# Altium schematic sheet InfoDisplayLEDs.SchDoc
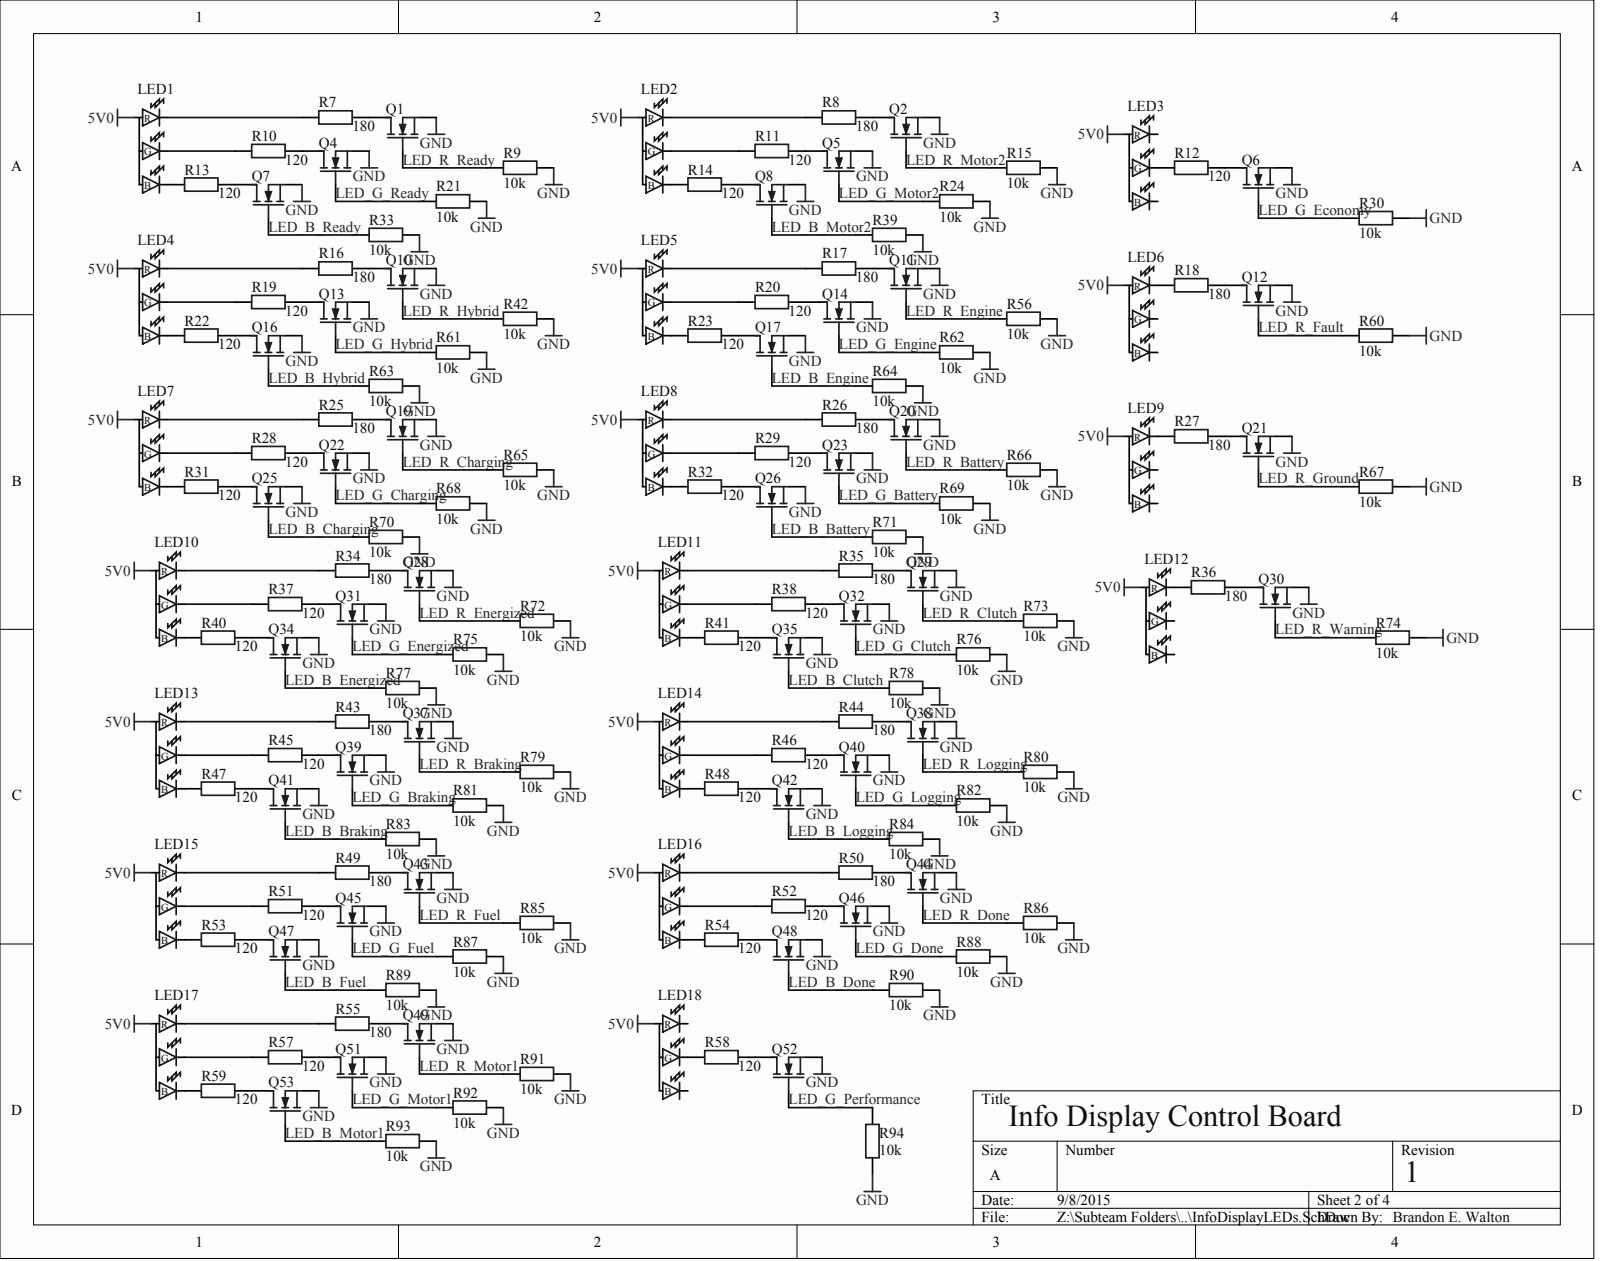
[diagram p0: InfoDisplayLEDs.SchDoc]

## Parts
LED1  LED
LED2  LED
LED3  LED
LED4  LED
LED5  LED
LED6  LED
LED7  LED
LED8  LED
LED9  LED
LED10  LED
LED11  LED
LED12  LED
LED13  LED
LED14  LED
LED15  LED
LED16  LED
LED17  LED
LED18  LED
Q1  MOSFET-N  (value not drawn)
Q2  MOSFET-N  (value not drawn)
Q4  MOSFET-N  (value not drawn)
Q5  MOSFET-N  (value not drawn)
Q6  MOSFET-N  (value not drawn)
Q7  MOSFET-N  (value not drawn)
Q8  MOSFET-N  (value not drawn)
Q10  MOSFET-N  (value not drawn)
Q11  MOSFET-N  (value not drawn)
Q12  MOSFET-N  (value not drawn)
Q13  MOSFET-N  (value not drawn)
Q14  MOSFET-N  (value not drawn)
Q16  MOSFET-N  (value not drawn)
Q17  MOSFET-N  (value not drawn)
Q19  MOSFET-N  (value not drawn)
Q20  MOSFET-N  (value not drawn)
Q21  MOSFET-N  (value not drawn)
Q22  MOSFET-N  (value not drawn)
Q23  MOSFET-N  (value not drawn)
Q25  MOSFET-N  (value not drawn)
Q26  MOSFET-N  (value not drawn)
Q28  MOSFET-N  (value not drawn)
Q29  MOSFET-N  (value not drawn)
Q30  MOSFET-N  (value not drawn)
Q31  MOSFET-N  (value not drawn)
Q32  MOSFET-N  (value not drawn)
Q34  MOSFET-N  (value not drawn)
Q35  MOSFET-N  (value not drawn)
Q37  MOSFET-N  (value not drawn)
Q38  MOSFET-N  (value not drawn)
Q39  MOSFET-N  (value not drawn)
Q40  MOSFET-N  (value not drawn)
Q41  MOSFET-N  (value not drawn)
Q42  MOSFET-N  (value not drawn)
Q43  MOSFET-N  (value not drawn)
Q44  MOSFET-N  (value not drawn)
Q45  MOSFET-N  (value not drawn)
Q46  MOSFET-N  (value not drawn)
Q47  MOSFET-N  (value not drawn)
Q48  MOSFET-N  (value not drawn)
Q49  MOSFET-N  (value not drawn)
Q51  MOSFET-N  (value not drawn)
Q52  MOSFET-N  (value not drawn)
Q53  MOSFET-N  (value not drawn)
R7  value 180  (hidden comment: Res2)
R8  value 180  (hidden comment: Res2)
R9  value 10k  (hidden comment: 311-10KGRCT-ND)
R10  value 120  (hidden comment: Res2)
R11  value 120  (hidden comment: Res2)
R12  value 120  (hidden comment: Res2)
R13  value 120  (hidden comment: Res2)
R14  value 120  (hidden comment: Res2)
R15  value 10k  (hidden comment: 311-10KGRCT-ND)
R16  value 180  (hidden comment: Res2)
R17  value 180  (hidden comment: Res2)
R18  value 180  (hidden comment: Res2)
R19  value 120  (hidden comment: Res2)
R20  value 120  (hidden comment: Res2)
R21  value 10k  (hidden comment: 311-10KGRCT-ND)
R22  value 120  (hidden comment: Res2)
R23  value 120  (hidden comment: Res2)
R24  value 10k  (hidden comment: 311-10KGRCT-ND)
R25  value 180  (hidden comment: Res2)
R26  value 180  (hidden comment: Res2)
R27  value 180  (hidden comment: Res2)
R28  value 120  (hidden comment: Res2)
R29  value 120  (hidden comment: Res2)
R30  value 10k  (hidden comment: 311-10KGRCT-ND)
R31  value 120  (hidden comment: Res2)
R32  value 120  (hidden comment: Res2)
R33  value 10k  (hidden comment: 311-10KGRCT-ND)
R34  value 180  (hidden comment: Res2)
R35  value 180  (hidden comment: Res2)
R36  value 180  (hidden comment: Res2)
R37  value 120  (hidden comment: Res2)
R38  value 120  (hidden comment: Res2)
R39  value 10k  (hidden comment: 311-10KGRCT-ND)
R40  value 120  (hidden comment: Res2)
R41  value 120  (hidden comment: Res2)
R42  value 10k  (hidden comment: 311-10KGRCT-ND)
R43  value 180  (hidden comment: Res2)
R44  value 180  (hidden comment: Res2)
R45  value 120  (hidden comment: Res2)
R46  value 120  (hidden comment: Res2)
R47  value 120  (hidden comment: Res2)
R48  value 120  (hidden comment: Res2)
R49  value 180  (hidden comment: Res2)
R50  value 180  (hidden comment: Res2)
R51  value 120  (hidden comment: Res2)
R52  value 120  (hidden comment: Res2)
R53  value 120  (hidden comment: Res2)
R54  value 120  (hidden comment: Res2)
R55  value 180  (hidden comment: Res2)
R56  value 10k  (hidden comment: 311-10KGRCT-ND)
R57  value 120  (hidden comment: Res2)
R58  value 120  (hidden comment: Res2)
R59  value 120  (hidden comment: Res2)
R60  value 10k  (hidden comment: 311-10KGRCT-ND)
R61  value 10k  (hidden comment: 311-10KGRCT-ND)
R62  value 10k  (hidden comment: 311-10KGRCT-ND)
R63  value 10k  (hidden comment: 311-10KGRCT-ND)
R64  value 10k  (hidden comment: 311-10KGRCT-ND)
R65  value 10k  (hidden comment: 311-10KGRCT-ND)
R66  value 10k  (hidden comment: 311-10KGRCT-ND)
R67  value 10k  (hidden comment: 311-10KGRCT-ND)
R68  value 10k  (hidden comment: 311-10KGRCT-ND)
R69  value 10k  (hidden comment: 311-10KGRCT-ND)
R70  value 10k  (hidden comment: 311-10KGRCT-ND)
R71  value 10k  (hidden comment: 311-10KGRCT-ND)
R72  value 10k  (hidden comment: 311-10KGRCT-ND)
R73  value 10k  (hidden comment: 311-10KGRCT-ND)
R74  value 10k  (hidden comment: 311-10KGRCT-ND)
R75  value 10k  (hidden comment: 311-10KGRCT-ND)
R76  value 10k  (hidden comment: 311-10KGRCT-ND)
R77  value 10k  (hidden comment: 311-10KGRCT-ND)
R78  value 10k  (hidden comment: 311-10KGRCT-ND)
R79  value 10k  (hidden comment: 311-10KGRCT-ND)
R80  value 10k  (hidden comment: 311-10KGRCT-ND)
R81  value 10k  (hidden comment: 311-10KGRCT-ND)
R82  value 10k  (hidden comment: 311-10KGRCT-ND)
R83  value 10k  (hidden comment: 311-10KGRCT-ND)
R84  value 10k  (hidden comment: 311-10KGRCT-ND)
R85  value 10k  (hidden comment: 311-10KGRCT-ND)
R86  value 10k  (hidden comment: 311-10KGRCT-ND)
R87  value 10k  (hidden comment: 311-10KGRCT-ND)
R88  value 10k  (hidden comment: 311-10KGRCT-ND)
R89  value 10k  (hidden comment: 311-10KGRCT-ND)
R90  value 10k  (hidden comment: 311-10KGRCT-ND)
R91  value 10k  (hidden comment: 311-10KGRCT-ND)
R92  value 10k  (hidden comment: 311-10KGRCT-ND)
R93  value 10k  (hidden comment: 311-10KGRCT-ND)
R94  value 10k  (hidden comment: 311-10KGRCT-ND)

## Nets
5V0: LED1.2(R) LED2.2(R) LED3.2(R) LED4.2(R) LED5.2(R) LED6.2(R) LED7.2(R) LED8.2(R) LED9.2(R) LED10.2(R) LED11.2(R) LED12.2(R) LED13.2(R) LED14.2(R) LED15.2(R) LED16.2(R) LED17.2(R) LED18.2(R)
GND: Q1.3 Q2.3 Q4.3 Q5.3 Q6.3 Q7.3 Q8.3 Q10.3 Q11.3 Q12.3 Q13.3 Q14.3 Q16.3 Q17.3 Q19.3 Q20.3 Q21.3 Q22.3 Q23.3 Q25.3 Q26.3 Q28.3 Q29.3 Q30.3 Q31.3 Q32.3 Q34.3 Q35.3 Q37.3 Q38.3 Q39.3 Q40.3 Q41.3 Q42.3 Q43.3 Q44.3 Q45.3 Q46.3 Q47.3 Q48.3 Q49.3 Q51.3 Q52.3 Q53.3 R9.2 R15.2 R21.2 R24.2 R30.1 R33.2 R39.2 R42.2 R56.2 R60.2 R61.2 R62.2 R63.2 R64.2 R65.2 R66.2 R67.2 R68.2 R69.2 R70.2 R71.2 R72.2 R73.2 R74.2 R75.2 R76.2 R77.2 R78.2 R79.2 R80.2 R81.2 R82.2 R83.2 R84.2 R85.2 R86.2 R87.2 R88.2 R89.2 R90.2 R91.2 R92.2 R93.2 R94.1
LED_B_BATTERY: Q26.2 R71.1
LED_B_BRAKING: Q41.2 R83.1
LED_B_CHARGING: Q25.2 R70.1
LED_B_CLUTCH: Q35.2 R78.1
LED_B_DONE: Q48.2 R90.1
LED_B_ENERGIZED: Q34.2 R77.1
LED_B_ENGINE: Q17.2 R64.1
LED_B_FUEL: Q47.2 R89.1
LED_B_HYBRID: Q16.2 R63.1
LED_B_LOGGING: Q42.2 R84.1
LED_B_MOTOR1: Q53.2 R93.1
LED_B_MOTOR2: Q8.2 R39.1
LED_B_READY: Q7.2 R33.1
LED_G_BATTERY: Q23.2 R69.1
LED_G_BRAKING: Q39.2 R81.1
LED_G_CHARGING: Q22.2 R68.1
LED_G_CLUTCH: Q32.2 R76.1
LED_G_DONE: Q46.2 R88.1
LED_G_ECONOMY: Q6.2 R30.2
LED_G_ENERGIZED: Q31.2 R75.1
LED_G_ENGINE: Q14.2 R62.1
LED_G_FUEL: Q45.2 R87.1
LED_G_HYBRID: Q13.2 R61.1
LED_G_LOGGING: Q40.2 R82.1
LED_G_MOTOR1: Q51.2 R92.1
LED_G_MOTOR2: Q5.2 R24.1
LED_G_PERFORMANCE: Q52.2 R94.2
LED_G_READY: Q4.2 R21.1
LED_R_BATTERY: Q20.2 R66.1
LED_R_BRAKING: Q37.2 R79.1
LED_R_CHARGING: Q19.2 R65.1
LED_R_CLUTCH: Q29.2 R73.1
LED_R_DONE: Q44.2 R86.1
LED_R_ENERGIZED: Q28.2 R72.1
LED_R_ENGINE: Q11.2 R56.1
LED_R_FAULT: Q12.2 R60.1
LED_R_FUEL: Q43.2 R85.1
LED_R_GROUND: Q21.2 R67.1
LED_R_HYBRID: Q10.2 R42.1
LED_R_LOGGING: Q38.2 R80.1
LED_R_MOTOR1: Q49.2 R91.1
LED_R_MOTOR2: Q2.2 R15.1
LED_R_READY: Q1.2 R9.1
LED_R_WARNING: Q30.2 R74.1
NetLED1_1: LED1.1 R7.2
NetLED1_3: LED1.3(B) R13.2
NetLED1_4: LED1.4(G) R10.2
NetLED2_1: LED2.1 R8.2
NetLED2_3: LED2.3(B) R14.2
NetLED2_4: LED2.4(G) R11.2
NetLED3_4: LED3.4(G) R12.2
NetLED4_1: LED4.1 R16.2
NetLED4_3: LED4.3(B) R22.2
NetLED4_4: LED4.4(G) R19.2
NetLED5_1: LED5.1 R17.2
NetLED5_3: LED5.3(B) R23.2
NetLED5_4: LED5.4(G) R20.2
NetLED6_1: LED6.1 R18.2
NetLED7_1: LED7.1 R25.2
NetLED7_3: LED7.3(B) R31.2
NetLED7_4: LED7.4(G) R28.2
NetLED8_1: LED8.1 R26.2
NetLED8_3: LED8.3(B) R32.2
NetLED8_4: LED8.4(G) R29.2
NetLED9_1: LED9.1 R27.2
NetLED10_1: LED10.1 R34.2
NetLED10_3: LED10.3(B) R40.2
NetLED10_4: LED10.4(G) R37.2
NetLED11_1: LED11.1 R35.2
NetLED11_3: LED11.3(B) R41.2
NetLED11_4: LED11.4(G) R38.2
NetLED12_1: LED12.1 R36.2
NetLED13_1: LED13.1 R43.2
NetLED13_3: LED13.3(B) R47.2
NetLED13_4: LED13.4(G) R45.2
NetLED14_1: LED14.1 R44.2
NetLED14_3: LED14.3(B) R48.2
NetLED14_4: LED14.4(G) R46.2
NetLED15_1: LED15.1 R49.2
NetLED15_3: LED15.3(B) R53.2
NetLED15_4: LED15.4(G) R51.2
NetLED16_1: LED16.1 R50.2
NetLED16_3: LED16.3(B) R54.2
NetLED16_4: LED16.4(G) R52.2
NetLED17_1: LED17.1 R55.2
NetLED17_3: LED17.3(B) R59.2
NetLED17_4: LED17.4(G) R57.2
NetLED18_4: LED18.4(G) R58.2
NetQ1_1: Q1.1 R7.1
NetQ2_1: Q2.1 R8.1
NetQ4_1: Q4.1 R10.1
NetQ5_1: Q5.1 R11.1
NetQ6_1: Q6.1 R12.1
NetQ7_1: Q7.1 R13.1
NetQ8_1: Q8.1 R14.1
NetQ10_1: Q10.1 R16.1
NetQ11_1: Q11.1 R17.1
NetQ12_1: Q12.1 R18.1
NetQ13_1: Q13.1 R19.1
NetQ14_1: Q14.1 R20.1
NetQ16_1: Q16.1 R22.1
NetQ17_1: Q17.1 R23.1
NetQ19_1: Q19.1 R25.1
NetQ20_1: Q20.1 R26.1
NetQ21_1: Q21.1 R27.1
NetQ22_1: Q22.1 R28.1
NetQ23_1: Q23.1 R29.1
NetQ25_1: Q25.1 R31.1
NetQ26_1: Q26.1 R32.1
NetQ28_1: Q28.1 R34.1
NetQ29_1: Q29.1 R35.1
NetQ30_1: Q30.1 R36.1
NetQ31_1: Q31.1 R37.1
NetQ32_1: Q32.1 R38.1
NetQ34_1: Q34.1 R40.1
NetQ35_1: Q35.1 R41.1
NetQ37_1: Q37.1 R43.1
NetQ38_1: Q38.1 R44.1
NetQ39_1: Q39.1 R45.1
NetQ40_1: Q40.1 R46.1
NetQ41_1: Q41.1 R47.1
NetQ42_1: Q42.1 R48.1
NetQ43_1: Q43.1 R49.1
NetQ44_1: Q44.1 R50.1
NetQ45_1: Q45.1 R51.1
NetQ46_1: Q46.1 R52.1
NetQ47_1: Q47.1 R53.1
NetQ48_1: Q48.1 R54.1
NetQ49_1: Q49.1 R55.1
NetQ51_1: Q51.1 R57.1
NetQ52_1: Q52.1 R58.1
NetQ53_1: Q53.1 R59.1

Not connected: LED3 1 3(B); LED6 3(B) 4(G); LED9 3(B) 4(G); LED12 3(B) 4(G); LED18 1 3(B)

## Library data of the parts
LED1 = (no symbol name): PartNumber: CLVBA-FKA-CAEDH8BBB7A363; Digikey: CLVBA-FKA-CAEDH8BBB7A363CT-ND
Q32 = (no symbol name): PartNumber: SSM3K15AFS,LF; Published: 8-Jun-2000; LatestRevisionDate: 17-Jul-2002; LatestRevisionNote: Re-released for DXP Platform.; PackageVersion: 1995/1996; PackageReference: E3; PackageDescription: TO, Flat Index; 3 In-Line, Axial Leads; Body Dia. 4.6mm; Lead Dia. 0.5 mm (max); Digikey: SSM3K15AFSLFCT-ND; Publisher: Altium Limited
R33 R39 = (no symbol name): Value: 10k; Published: 8-Jun-2000; LatestRevisionDate: 17-Jul-2002; LatestRevisionNote: Re-released for DXP Platform.; PackageReference: AXIAL-0.4; PackageDescription: Axial Device, Thru-Hole; 2 Leads; 0.4 in Pin Spacing; Digikey: 311-10KGRCT-ND; Publisher: Altium Limited
R35 = (no symbol name): PartNumber: ESR03EZPJ101; Published: 8-Jun-2000; LatestRevisionDate: 17-Jul-2002; LatestRevisionNote: Re-released for DXP Platform.; PackageReference: AXIAL-0.4; PackageDescription: Axial Device, Thru-Hole; 2 Leads; 0.4 in Pin Spacing; Digikey: RNCP0603FTD180RCT-ND; Publisher: Altium Limited
R41 = (no symbol name): PartNumber: CRCW120622R0JNEA; Published: 8-Jun-2000; LatestRevisionDate: 17-Jul-2002; LatestRevisionNote: Re-released for DXP Platform.; PackageReference: AXIAL-0.4; PackageDescription: Axial Device, Thru-Hole; 2 Leads; 0.4 in Pin Spacing; Digikey: 311-120GRCT-ND; Publisher: Altium Limited
LED2-LED18 Q1 Q2 Q4-Q8 Q10-Q14 Q16 Q17 Q19-Q23 Q25 Q26 Q28-Q31 Q34 Q35 Q37-Q49 Q51-Q53 R7-R32 R34 R36-R38 R40 R42-R94 = (no symbol name)
  LED2-LED18: Digikey: CLVBA-FKA-CAEDH8BBB7A363CT-ND
  Q1 Q2 Q4-Q8 Q10-Q14 Q16 Q17 Q19-Q23 Q25 Q26 Q28-Q31 Q34 Q35 Q37-Q49 Q51-Q53: Published: 8-Jun-2000; LatestRevisionDate: 17-Jul-2002; LatestRevisionNote: Re-released for DXP Platform.; PackageVersion: 1995/1996; PackageReference: E3; PackageDescription: TO, Flat Index; 3 In-Line, Axial Leads; Body Dia. 4.6mm; Lead Dia. 0.5 mm (max); Digikey: SSM3K15AFSLFCT-ND
  R7 R8 R16-R18 R25-R27 R34 R36 R43 R44 R49 R50 R55: Published: 8-Jun-2000; LatestRevisionDate: 17-Jul-2002; LatestRevisionNote: Re-released for DXP Platform.; PackageReference: AXIAL-0.4; PackageDescription: Axial Device, Thru-Hole; 2 Leads; 0.4 in Pin Spacing; Digikey: RNCP0603FTD180RCT-ND
  R9 R15 R21 R24 R30 R42 R56 R60-R94: Published: 8-Jun-2000; LatestRevisionDate: 17-Jul-2002; LatestRevisionNote: Re-released for DXP Platform.; PackageReference: AXIAL-0.4; PackageDescription: Axial Device, Thru-Hole; 2 Leads; 0.4 in Pin Spacing; Digikey: 311-10KGRCT-ND
  R10-R14 R19 R20 R22 R23 R28 R29 R31 R32 R37 R38 R40 R45-R48 R51-R54 R57-R59: Published: 8-Jun-2000; LatestRevisionDate: 17-Jul-2002; LatestRevisionNote: Re-released for DXP Platform.; PackageReference: AXIAL-0.4; PackageDescription: Axial Device, Thru-Hole; 2 Leads; 0.4 in Pin Spacing; Digikey: 311-120GRCT-ND
  Q1 Q2 Q4-Q8 Q10-Q14 Q16 Q17 Q19-Q23 Q25 Q26 Q28-Q31 Q34 Q35 Q37-Q49 Q51-Q53 R7-R32 R34 R36-R38 R40 R42-R94: Publisher: Altium Limited
